# Altium schematic sheet bbb_db25_cnc_cape.SchDoc
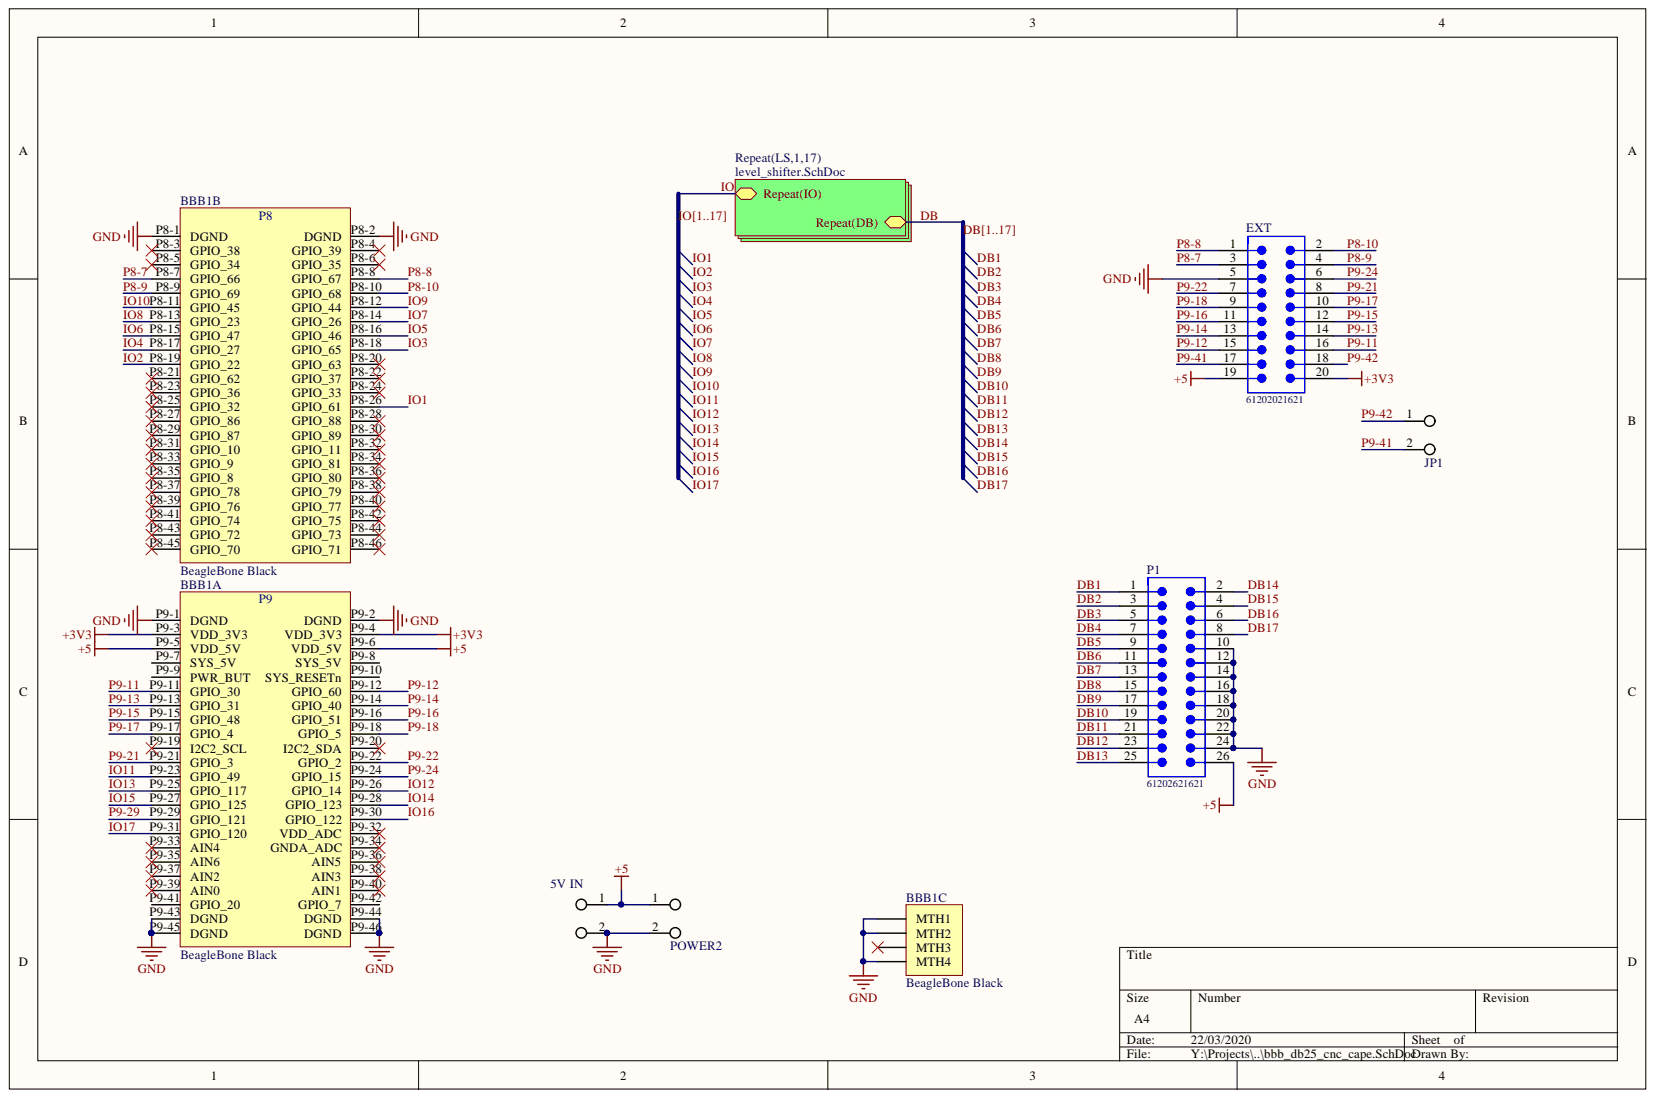
[diagram p0: bbb_db25_cnc_cape.SchDoc]

## Parts
5V_IN  Header 1x2  [Screw Terminal 1x2 5.08mm Vertical]  (value not drawn)
BBB1  BeagleBone Black  [BeagleBone_Black_Cape]  (parts A,B,C)
EXT  61202021621  [61202021621]
JP1  Header 1x2  [Header 1x2 100mil Vertical]  (value not drawn)
P1  61202621621  [61202621621]
POWER2  Header 1x2  [Screw Terminal 1x2 3.5mm Vertical]  (value not drawn)

## Nets
+3V3: BBB1.P9-3(VDD_3V3) BBB1.P9-4(VDD_3V3) EXT.20
+5: 5V_IN.1 BBB1.P9-5(VDD_5V) BBB1.P9-6(VDD_5V) EXT.19 P1.26 POWER2.1
GND: 5V_IN.2 BBB1.MTH1 BBB1.MTH2 BBB1.MTH4 BBB1.P8-1(DGND) BBB1.P8-2(DGND) BBB1.P9-1(DGND) BBB1.P9-2(DGND) BBB1.P9-43(DGND) BBB1.P9-44(DGND) BBB1.P9-45(DGND) BBB1.P9-46(DGND) EXT.5 P1.10 P1.12 P1.14 P1.16 P1.18 P1.20 P1.22 P1.24 POWER2.2
DB1: P1.1  [also labelled DB]
DB2: P1.3  [also labelled DB]
DB3: P1.5  [also labelled DB]
DB4: P1.7  [also labelled DB]
DB5: P1.9  [also labelled DB]
DB6: P1.11  [also labelled DB]
DB7: P1.13  [also labelled DB]
DB8: P1.15  [also labelled DB]
DB9: P1.17  [also labelled DB]
DB10: P1.19  [also labelled DB]
DB11: P1.21  [also labelled DB]
DB12: P1.23  [also labelled DB]
DB13: P1.25  [also labelled DB]
DB14: P1.2  [also labelled DB]
DB15: P1.4  [also labelled DB]
DB16: P1.6  [also labelled DB]
DB17: P1.8  [also labelled DB]
IO1: BBB1.P8-26(GPIO_61)  [also labelled IO]
IO2: BBB1.P8-19(GPIO_22)  [also labelled IO]
IO3: BBB1.P8-18(GPIO_65)  [also labelled IO]
IO4: BBB1.P8-17(GPIO_27)  [also labelled IO]
IO5: BBB1.P8-16(GPIO_46)  [also labelled IO]
IO6: BBB1.P8-15(GPIO_47)  [also labelled IO]
IO7: BBB1.P8-14(GPIO_26)  [also labelled IO]
IO8: BBB1.P8-13(GPIO_23)  [also labelled IO]
IO9: BBB1.P8-12(GPIO_44)  [also labelled IO]
IO10: BBB1.P8-11(GPIO_45)  [also labelled IO]
IO11: BBB1.P9-23(GPIO_49)  [also labelled IO]
IO12: BBB1.P9-26(GPIO_14)  [also labelled IO]
IO13: BBB1.P9-25(GPIO_117)  [also labelled IO]
IO14: BBB1.P9-28(GPIO_123)  [also labelled IO]
IO15: BBB1.P9-27(GPIO_125)  [also labelled IO]
IO16: BBB1.P9-30(GPIO_122)  [also labelled IO]
IO17: BBB1.P9-31(GPIO_120)  [also labelled IO]
P8-7: BBB1.P8-7(GPIO_66) EXT.3
P8-8: BBB1.P8-8(GPIO_67) EXT.1
P8-9: BBB1.P8-9(GPIO_69) EXT.4
P8-10: BBB1.P8-10(GPIO_68) EXT.2
P9-11: BBB1.P9-11(GPIO_30) EXT.16
P9-12: BBB1.P9-12(GPIO_60) EXT.15
P9-13: BBB1.P9-13(GPIO_31) EXT.14
P9-14: BBB1.P9-14(GPIO_40) EXT.13
P9-15: BBB1.P9-15(GPIO_48) EXT.12
P9-16: BBB1.P9-16(GPIO_51) EXT.11
P9-17: BBB1.P9-17(GPIO_4) EXT.10
P9-18: BBB1.P9-18(GPIO_5) EXT.9
P9-21: BBB1.P9-21(GPIO_3) EXT.8
P9-22: BBB1.P9-22(GPIO_2) EXT.7
P9-24: BBB1.P9-24(GPIO_15) EXT.6
P9-29: BBB1.P9-29(GPIO_121)
P9-41: EXT.17 JP1.2
P9-42: EXT.18 JP1.1
NetBBB1_MTH3: BBB1.MTH3
NetBBB1_P8-3: BBB1.P8-3(GPIO_38)
NetBBB1_P8-4: BBB1.P8-4(GPIO_39)
NetBBB1_P8-5: BBB1.P8-5(GPIO_34)
NetBBB1_P8-6: BBB1.P8-6(GPIO_35)
NetBBB1_P8-20: BBB1.P8-20(GPIO_63)
NetBBB1_P8-21: BBB1.P8-21(GPIO_62)
NetBBB1_P8-22: BBB1.P8-22(GPIO_37)
NetBBB1_P8-23: BBB1.P8-23(GPIO_36)
NetBBB1_P8-24: BBB1.P8-24(GPIO_33)
NetBBB1_P8-25: BBB1.P8-25(GPIO_32)
NetBBB1_P8-27: BBB1.P8-27(GPIO_86)
NetBBB1_P8-28: BBB1.P8-28(GPIO_88)
NetBBB1_P8-29: BBB1.P8-29(GPIO_87)
NetBBB1_P8-30: BBB1.P8-30(GPIO_89)
NetBBB1_P8-31: BBB1.P8-31(GPIO_10)
NetBBB1_P8-32: BBB1.P8-32(GPIO_11)
NetBBB1_P8-33: BBB1.P8-33(GPIO_9)
NetBBB1_P8-34: BBB1.P8-34(GPIO_81)
NetBBB1_P8-35: BBB1.P8-35(GPIO_8)
NetBBB1_P8-36: BBB1.P8-36(GPIO_80)
NetBBB1_P8-37: BBB1.P8-37(GPIO_78)
NetBBB1_P8-38: BBB1.P8-38(GPIO_79)
NetBBB1_P8-39: BBB1.P8-39(GPIO_76)
NetBBB1_P8-40: BBB1.P8-40(GPIO_77)
NetBBB1_P8-41: BBB1.P8-41(GPIO_74)
NetBBB1_P8-42: BBB1.P8-42(GPIO_75)
NetBBB1_P8-43: BBB1.P8-43(GPIO_72)
NetBBB1_P8-44: BBB1.P8-44(GPIO_73)
NetBBB1_P8-45: BBB1.P8-45(GPIO_70)
NetBBB1_P8-46: BBB1.P8-46(GPIO_71)
NetBBB1_P9-7: BBB1.P9-7(SYS_5V)
NetBBB1_P9-8: BBB1.P9-8(SYS_5V)
NetBBB1_P9-9: BBB1.P9-9(PWR_BUT)
NetBBB1_P9-10: BBB1.P9-10(SYS_RESETn)
NetBBB1_P9-19: BBB1.P9-19(I2C2_SCL)
NetBBB1_P9-20: BBB1.P9-20(I2C2_SDA)
NetBBB1_P9-32: BBB1.P9-32(VDD_ADC)
NetBBB1_P9-33: BBB1.P9-33(AIN4)
NetBBB1_P9-34: BBB1.P9-34(GNDA_ADC)
NetBBB1_P9-35: BBB1.P9-35(AIN6)
NetBBB1_P9-36: BBB1.P9-36(AIN5)
NetBBB1_P9-37: BBB1.P9-37(AIN2)
NetBBB1_P9-38: BBB1.P9-38(AIN3)
NetBBB1_P9-39: BBB1.P9-39(AIN0)
NetBBB1_P9-40: BBB1.P9-40(AIN1)
NetBBB1_P9-41: BBB1.P9-41(GPIO_20)
NetBBB1_P9-42: BBB1.P9-42(GPIO_7)

## Library data of the parts
5V_IN JP1 POWER2 = Header 1x2: Standard Header Connector; Component Kind: Standard; Library Name: BB_Connectors.SchLib
EXT = 61202021621: Male Box Header WR-BHD, THT, Vertical, pitch 2.54 mm, 20 pins; Contact Resistance: 20 mOhm; Pin Number: 20; Pitch: 2.54 mm; Withstanding Voltage: 500 VAC; Working Voltage: 250 VAC; Component Kind: Standard; ComponentLink1Description: Manufacturer; ComponentLink1URL: http://www.we-online.de; ComponentLink2Description: Datasheet; ComponentLink2URL: https://katalog.we-online.de/em/datasheet/6120xx21621.pdf; Gender: Male; Library Name: Altium_WR-BHD_rev19a.IntLib; Mounting Technology: THT; Operating Temperature: -40 to 125 degC; Orientation: Vertical; Packaging: Tray; Part Number: 61202021621; Published Date: August 2018; Publisher: Wurth Elektronik
P1 = 61202621621: Male Box Header WR-BHD, THT, Vertical, pitch 2.54 mm, 26 pins; Contact Resistance: 20 mOhm; Pin Number: 26; Pitch: 2.54 mm; Withstanding Voltage: 500 VAC; Working Voltage: 250 VAC; Component Kind: Standard; ComponentLink1Description: Manufacturer; ComponentLink1URL: http://www.we-online.de; ComponentLink2Description: Datasheet; ComponentLink2URL: https://katalog.we-online.de/em/datasheet/6120xx21621.pdf; Gender: Male; Library Name: Altium_WR-BHD_rev19a.IntLib; Mounting Technology: THT; Operating Temperature: -40 to 125 degC; Orientation: Vertical; Packaging: Tray; Part Number: 61202621621; Published Date: August 2018; Publisher: Wurth Elektronik
BBB1 = BeagleBone Black: Component Kind: Standard; Description: BeagleBone Black; Library Name: BB_Boards.SchLib
